# Altium schematic sheet Stage2D_active_feedback.SchDoc
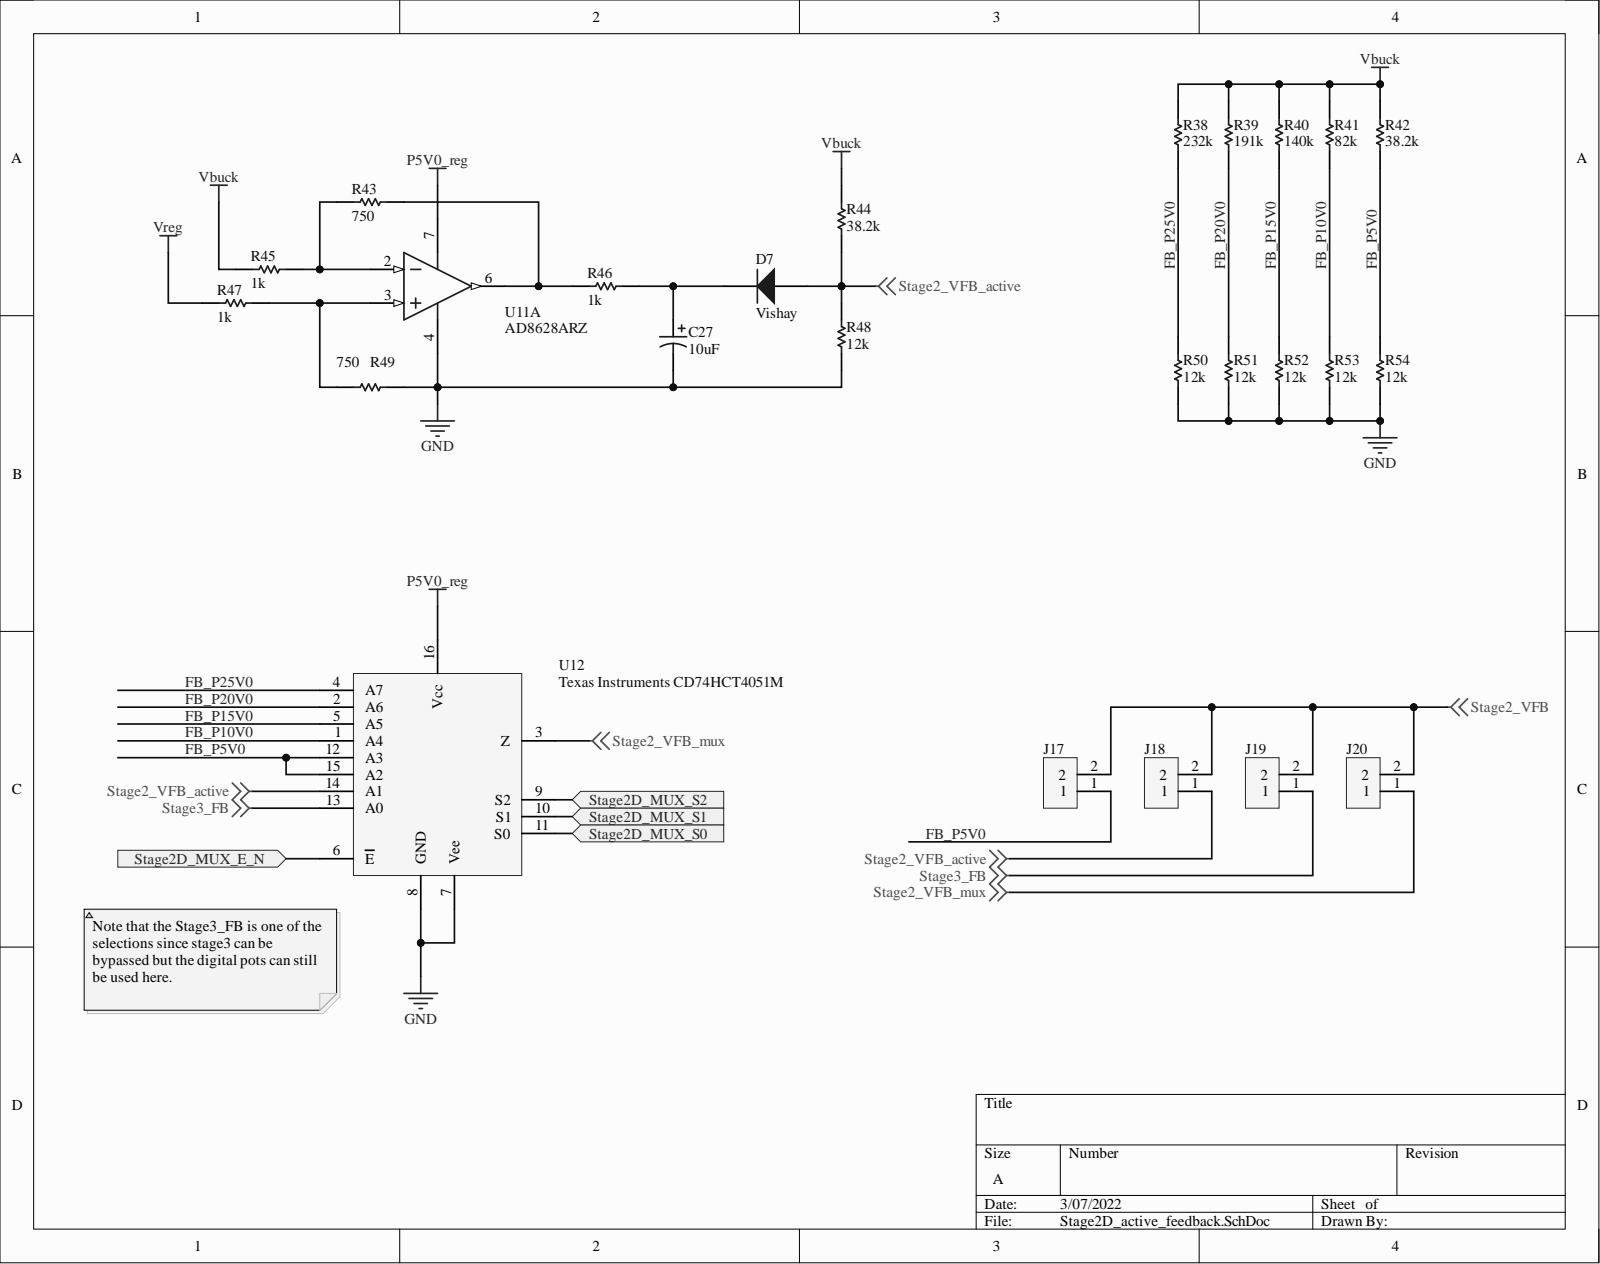
[diagram p0: Stage2D_active_feedback.SchDoc]

## Parts
C27  10uF  [PCB-45uljczbzgwzo9m7ql45-1]
D7  Vishay  [PCB-aq0vep24p5p345c98j9h-1]
J17  (no value)  [PCB-omgsbkhp90n7lpri88og-1]
J18  (no value)  [PCB-omgsbkhp90n7lpri88og-1]
J19  (no value)  [PCB-omgsbkhp90n7lpri88og-1]
J20  (no value)  [PCB-omgsbkhp90n7lpri88og-1]
R38  232k  [RESC2013X60X35LL10T20]
R39  191k  [RESC2013X60X35LL10T20]
R40  140k  [RESC2013X60X35LL10T20]
R41  82k  [RESC2013X60X35LL10T20]
R42  38.2k  [RESC2013X60X35LL10T20]
R43  750  [RESC2013X60X35NL10T20]
R44  38.2k  [RESC2013X60X35LL10T20]
R45  1k  [PCB-0002-00367-1]
R46  1k  [PCB-0002-00367-1]
R47  1k  [PCB-0002-00367-1]
R48  12k  [RESC2013X60X35LL10T20]
R49  750  [RESC2013X60X35NL10T20]
R50  12k  [RESC2013X60X35LL10T20]
R51  12k  [RESC2013X60X35LL10T20]
R52  12k  [RESC2013X60X35LL10T20]
R53  12k  [RESC2013X60X35LL10T20]
R54  12k  [RESC2013X60X35LL10T20]
U11  AD8628ARZ  [ADI-R-8_L]  (part A)
U12  Texas Instruments CD74HCT4051M  [PCB-2tkut4cattjoiggpznnl-1]

## Ports
Stage2D_MUX_E_N, Stage2D_MUX_S0, Stage2D_MUX_S1, Stage2D_MUX_S2

## Nets
GND: C27.2 R48.1 R49.2 R50.1 R51.1 R52.1 R53.1 R54.1 U11.4 U12.7(Vee) U12.8(GND)
P5V0_reg: U11.7 U12.16(Vcc)
Stage2_VFB: J17.2 J18.2 J19.2 J20.2
Stage2_VFB_active: D7.2 J18.1 R44.1 R48.2 U12.14(A1)
Stage2_VFB_mux: J20.1 U12.3(Z)
Stage3_FB: J19.1 U12.13(A0)
Vbuck: R38.2 R39.2 R40.2 R41.2 R42.2 R44.2 R45.1
Vreg: R47.1
FB_P5V0: J17.1 R42.1 R54.2 U12.12(A3) U12.15(A2)
FB_P10V0: R41.1 R53.2 U12.1(A4)
FB_P15V0: R40.1 R52.2 U12.5(A5)
FB_P20V0: R39.1 R51.2 U12.2(A6)
FB_P25V0: R38.1 R50.2 U12.4(A7)
Stage2D_MUX_E_N: U12.6(E) ; port Stage2D_MUX_E_N
Stage2D_MUX_S0: U12.11(S0) ; port Stage2D_MUX_S0
Stage2D_MUX_S1: U12.10(S1) ; port Stage2D_MUX_S1
Stage2D_MUX_S2: U12.9(S2) ; port Stage2D_MUX_S2
NetC27_1: C27.1 D7.1 R46.2
NetR43_1: R43.1 R45.2 U11.2
NetR43_2: R43.2 R46.1 U11.6
NetR47_2: R47.2 R49.1 U11.3

## Library data of the parts
C27 = CMP-7610bd40367da9d7-6: Capacitor; Aluminum Electrolytic; Fc Radial Elec Cap 10uF 50V; Manufacturer Part Number: EEU-FC1H100L; Capacitance: 10.0 µF; Capacitance Tolerance: ±20%; Lead-Free Status: Lead Free; Voltage Rating (DC): 50.0 V; Component Kind: Standard; Dielectric Material: Aluminum Electrolytic; Library Name: My Personal Space; Lifecycle Status: Not Listed by Manufacturer; Mounting Style: Through Hole; Number of Pins: 2; Octopart URL: https://octopart.com/eeu-fc1h100l-panasonic-39478539; OctopartID: 7610bd40367da9d7; Operating Temperature: 105 °C (max); Packaging: Bulk; REACH SVHC Compliance: No SVHC; RoHS: Compliant; Size-Diameter: 5.00 mm; Size-Height: 11.0 mm; Size-Length: 11.0 mm
D7 = CMP-93ae58ff32594c69-2: S1G Series 1 A 400 V Surface Mount Glass Passivated Rectifier - DO-214AC; Manufacturer Part Number: S1G-E3/61T; Capacitance: 12.0 pF; Current Rating: 1.00 A; Lead-Free Status: Lead Free; Output Current: 1.00 A (max); Polarity: Standard; Voltage Rating (DC): 400 V; Component Kind: Standard; Library Name: My Personal Space; Mounting Style: Surface Mount; Number of Pins: 2; Octopart URL: http://octopart.com/s1g-e3%2F61t-vishay-39552145; OctopartID: 93ae58ff32594c69; Packaging: Cut Tape (CT), Tape & Reel (TR); RoHS: Compliant
J17-J20 = CMP-748fbcb73d6bab48-30: Pitch 2.54 mm, Single Row, 2pins; Component Kind: Standard; Library Name: My Personal Space
R38-R42 R44 R48 R50-R54 = CMP-1875647-2: RES SMD 38.3K OHM 1% 1/8W 0805; Manufacturer Part Number: RC0805FR-0738K3L; Case/Package (SI): 2012; Lead-Free Status: Lead Free; Power Rating: 0.125 W; Resistance: 38300.0 Ω; Voltage Rating (DC): 150.0 V; Case/Package: 0805; Component Kind: Standard; Library Name: My Personal Space; Mounting Style: Surface Mount; Octopart Url: https://octopart.com/rc0805fr-0738k3l-yageo-1177806; OctopartID: 2daae4b1f002f26d; Resistance Tolerance: ±1%; RoHS: Compliant; Size-Height: 0.0005 m; Size-Length: 0.002 m; Size-Width: 0.00125 m
R43 R49 = CMP-5384667-2: Thick Film Resistors - SMD 750 OHM 5%; Manufacturer Part Number: RC0805JR-13750RL; Lead-Free Status: Lead Free; Resistance: 750.0 Ω; Case/Package: 0805; Component Kind: Standard; Library Name: My Personal Space; Mounting Style: Surface Mount; Octopart Url: https://octopart.com/rc0805jr-13750rl-yageo-24906633; OctopartID: 510499727feb8ec3; Resistance Tolerance: ±5%; RoHS: Compliant
R45-R47 = CMP-b013793a15d56fd4-2: Res Thick Film 0805 1K Ohm 1% 1/8W ±100ppm/°C Molded SMD SMD Paper Tape on Plastic Reel; Manufacturer Part Number: CR0805-FX-1001ELF; Case/Package (SI): 2012; Power Rating: 125 mW; Resistance: 1.00 kΩ; Temperature Coefficient: -100 ppm/°C to 100 ppm/°C; Voltage Rating (DC): 150 V; Case/Package: 0805; Component Kind: Standard; Library Name: My Personal Space; Mounting Style: Surface Mount; Number of Pins: 2; Octopart URL: https://octopart.com/cr0805-fx-1001elf-bourns-2326688; OctopartID: b013793a15d56fd4; Operating Temperature: -55.0 °C to 155 °C; Packaging: Tape & Reel (TR), Cut Tape (CT); REACH SVHC Compliance: No SVHC; Resistance Tolerance: ±1%; RoHS: Compliant; Simulation: SIM-vjisq6q3u7vp6b0mgwb9-1; Size-Length: 2.01 mm; Size-Thickness: 508 µm; Size-Width: 1.24 mm
U11 = CMP-2260891-3: Single Channel RRIO Operational Amplifier, 2.5 MHz BW, 1 V/us SR, Industrial, 8-pin SOIC (R-8), Tube; Bandwidth: 2500000.0 Hz; Common Mode Rejection Ratio: 140.0 dB; Input Capacitance: 1e-11 F; Input Impedance: 5e+11 Ω; Lead-Free Status: Contains Lead; Mil-Spec: No; Number of Channels: 1; Slew Rate: 1.0 V/μs; Supply Current: 0.0011 A; Supply Voltage (DC): 5.0 V; Case/Package: SOIC; Component Kind: Standard; Gain Bandwidth Product: 2500000.0 Hz; Library Name: My Personal Space; Lifecycle Status: Active; Manufacturer Part Number: AD8628ARZ; Mounting Style: Surface Mount; Number of Circuits: 1; Number of Pins: 8; Octopart Url: https://octopart.com/ad8628arz-analog+devices-412641; OctopartID: cd22042ea3a512e5; Packaging: Tube; REACH SVHC Compliance: No SVHC; RoHS: Compliant
U12 = CMP-efcb26c6fc72300c-3: High Speed CMOS 8-Channel Analog Multiplexer/Demultiplexer with TTL inputs 16-SOIC -55 to 125; Lead-Free Status: Lead Free; Number of Bits: 8; Number of Circuits: 1; Polarity: Non-Inverting; Supply Current: 16.0 µA; Supply Voltage (DC): 5.00 V; Case/Package: SOIC; Component Kind: Standard; Library Name: My Personal Space; Lifecycle Status: Active; Manufacturer Part Number: CD74HCT4051M; Mounting Style: Surface Mount; Number of Channels: 8; Number of Outputs: 8; Number of Pins: 16; Octopart URL: http://octopart.com/cd74hct4051m-texas+instruments-472799; OctopartID: efcb26c6fc72300c; Packaging: Tube; REACH SVHC Compliance: No SVHC; RoHS: Compliant
